# Altium schematic sheet [6]ftdi.SchDoc
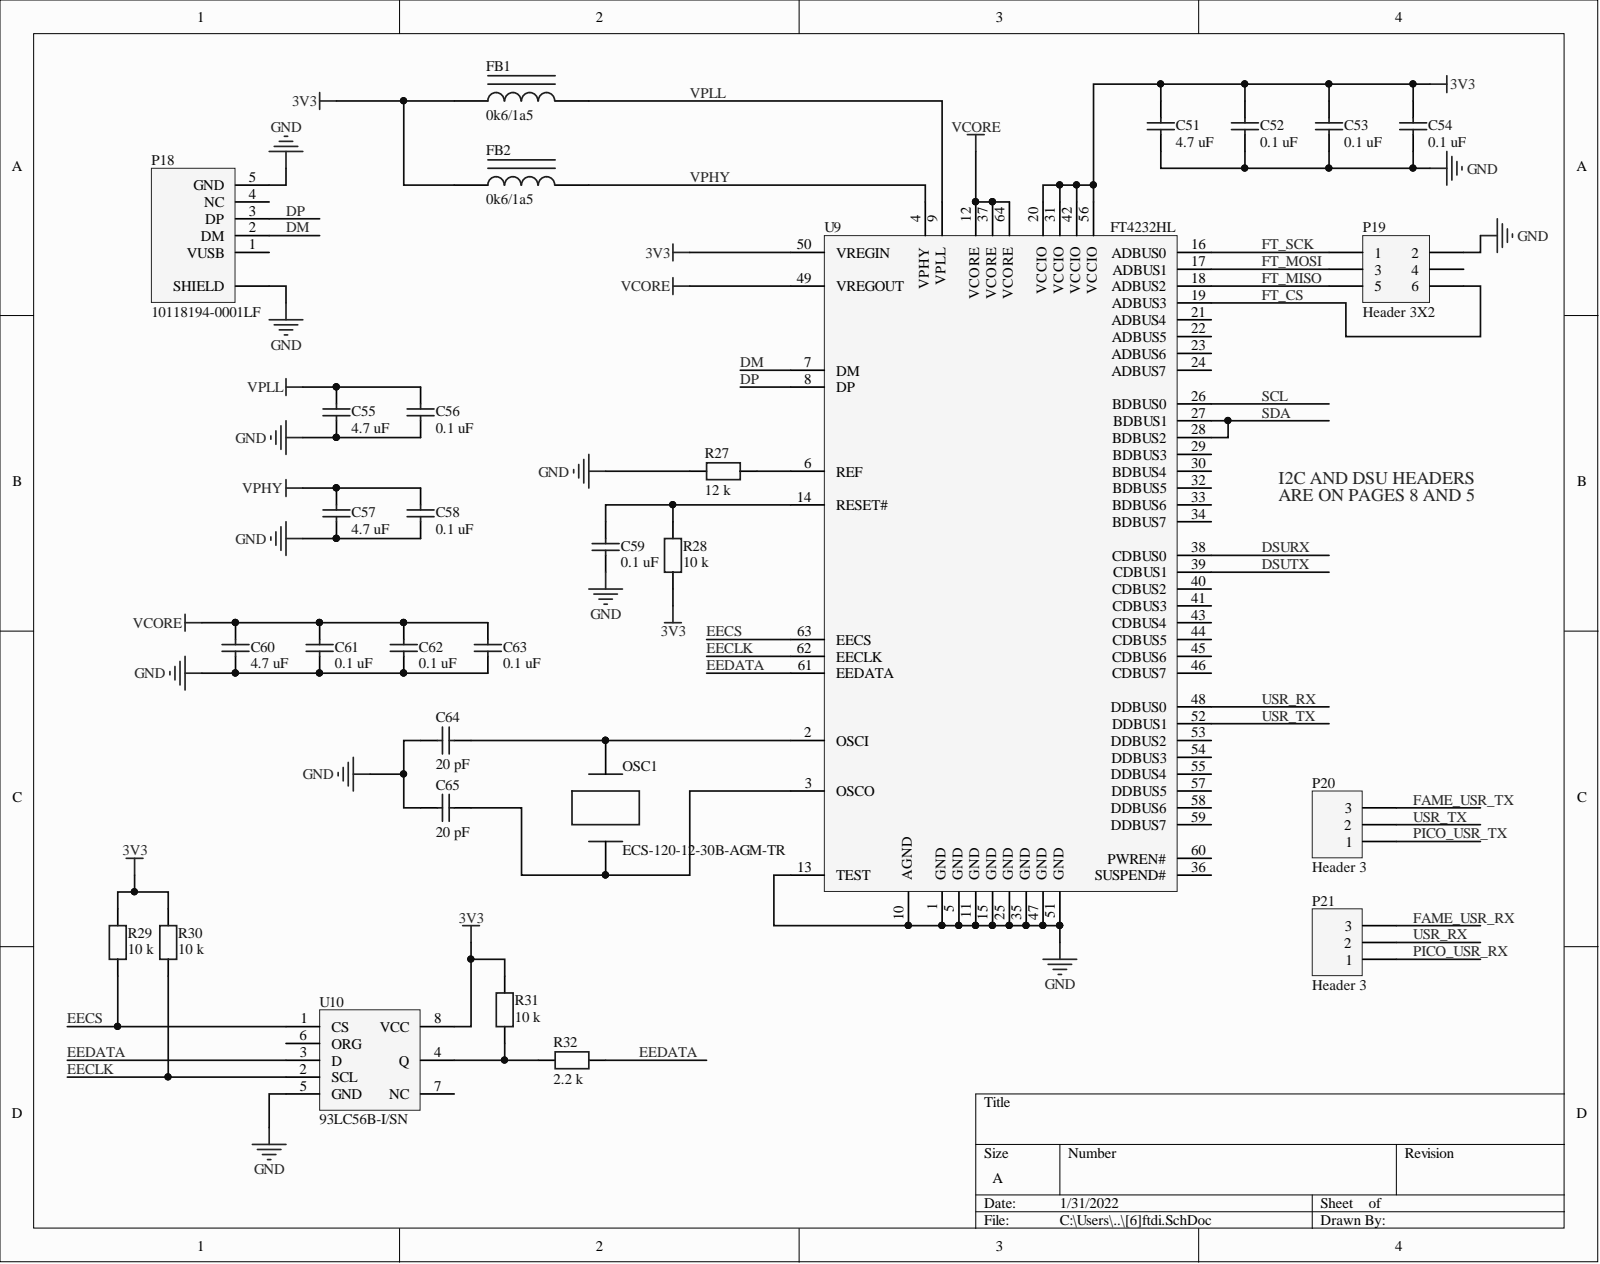
[diagram p0: [6]ftdi.SchDoc]

## Parts
C51  value 4.7 uF  [0603-CAP-ASM]  (hidden comment: Cap)
C52  value 0.1 uF  [0402-CAP-ASM]  (hidden comment: Cap)
C53  value 0.1 uF  [0402-CAP-ASM]  (hidden comment: Cap)
C54  value 0.1 uF  [0402-CAP-ASM]  (hidden comment: Cap)
C55  value 4.7 uF  [0603-CAP-ASM]  (hidden comment: Cap)
C56  value 0.1 uF  [0402-CAP-ASM]  (hidden comment: Cap)
C57  value 4.7 uF  [0603-CAP-ASM]  (hidden comment: Cap)
C58  value 0.1 uF  [0402-CAP-ASM]  (hidden comment: Cap)
C59  value 0.1 uF  [0402-CAP-ASM]  (hidden comment: Cap)
C60  value 4.7 uF  [0603-CAP-ASM]  (hidden comment: Cap)
C61  value 0.1 uF  [0402-CAP-ASM]  (hidden comment: Cap)
C62  value 0.1 uF  [0402-CAP-ASM]  (hidden comment: Cap)
C63  value 0.1 uF  [0402-CAP-ASM]  (hidden comment: Cap)
C64  value 20 pF  [0402-CAP-ASM]  (hidden comment: Cap)
C65  value 20 pF  [0402-CAP-ASM]  (hidden comment: Cap)
FB1  0k6/1a5  [1206-CAP-ASM]
FB2  0k6/1a5  [1206-CAP-ASM]
OSC1  ECS-120-12-30B-AGM-TR  [xtal 5032 ceramic]
P18  10118194-0001LF  [10118194-0001LF]
P19  Header 3X2  [HDR_2x3_2R54_V_M]
P20  Header 3  [Header 1x3 male]
P21  Header 3  [Header 1x3 male]
R27  value 12 k  [0402-RES-ASM]  (hidden comment: res)
R28  value 10 k  [0402-RES-ASM]  (hidden comment: res)
R29  value 10 k  [0402-RES-ASM]  (hidden comment: res)
R30  value 10 k  [0402-RES-ASM]  (hidden comment: res)
R31  value 10 k  [0402-RES-ASM]  (hidden comment: res)
R32  value 2.2 k  [0402-RES-ASM]  (hidden comment: res)
U9  FT4232HL  [LQFP64_N]
U10  93LC56B-I/SN  [SOIC-8]

## Nets
3V3: C51.2 C52.2 C53.2 C54.2 FB1.1 FB2.1 R28.1 R29.2 R30.2 R31.2 U9.20(VCCIO) U9.31(VCCIO) U9.42(VCCIO) U9.50(VREGIN) U9.56(VCCIO) U10.8(VCC)
GND: C51.1 C52.1 C53.1 C54.1 C55.1 C56.1 C57.1 C58.1 C59.1 C60.1 C61.1 C62.1 C63.1 C64.2 C65.2 P18.5(GND) P18.SHIELD P19.2 R27.2 U9.1(GND) U9.5(GND) U9.10(AGND) U9.11(GND) U9.13(TEST) U9.15(GND) U9.25(GND) U9.35(GND) U9.47(GND) U9.51(GND) U10.5(GND)
VCORE: C60.2 C61.2 C62.2 C63.2 U9.12(VCORE) U9.37(VCORE) U9.49(VREGOUT) U9.64(VCORE)
DM: P18.2(DM) U9.7(DM)
DP: P18.3(DP) U9.8(DP)
DSURX: U9.38(CDBUS0)
DSUTX: U9.39(CDBUS1)
EECLK: R30.1 U9.62(EECLK) U10.2(SCL)
EECS: R29.1 U9.63(EECS) U10.1(CS)
EEDATA: R32.1 U9.61(EEDATA) U10.3(D)
FAME_USR_RX: P21.3
FAME_USR_TX: P20.3
FT_CS: P19.6 U9.19(ADBUS3)
FT_MISO: P19.5 U9.18(ADBUS2)
FT_MOSI: P19.3 U9.17(ADBUS1)
FT_SCK: P19.1 U9.16(ADBUS0)
PICO_USR_RX: P21.1
PICO_USR_TX: P20.1
SCL: U9.26(BDBUS0)
SDA: U9.27(BDBUS1) U9.28(BDBUS2)
USR_RX: P21.2 U9.48(DDBUS0)
USR_TX: P20.2 U9.52(DDBUS1)
VPHY: C57.2 C58.2 FB2.2 U9.4(VPHY)
VPLL: C55.2 C56.2 FB1.2 U9.9(VPLL)
NetC59_2: C59.2 R28.2 U9.14(RESET#)
NetC64_1: C64.1 OSC1.2 U9.2(OSCI)
NetC65_1: C65.1 OSC1.1 U9.3(OSCO)
NetR27_1: R27.1 U9.6(REF)
NetR31_1: R31.1 R32.2 U10.4(Q)

Not connected: P18 1(VUSB) 4(NC); P19 4; U9 21(ADBUS4) 22(ADBUS5) 23(ADBUS6) 24(ADBUS7) 29(BDBUS3) 30(BDBUS4) 32(BDBUS5) 33(BDBUS6) 34(BDBUS7) 36(SUSPEND#) 40(CDBUS2) 41(CDBUS3) 43(CDBUS4) 44(CDBUS5) 45(CDBUS6) 46(CDBUS7) 53(DDBUS2) 54(DDBUS3) 55(DDBUS4) 57(DDBUS5) 58(DDBUS6) 59(DDBUS7) 60(PWREN#); U10 6(ORG) 7(NC)

## Library data of the parts
C51 C55 C57 C60 = Cap: CAP CER 4.7UF 25V JB 0603; Component Kind: Standard; Library Name: Miscellaneous Devices.IntLib; Supplier Part Number: 445-11253-1-ND
C52-C54 C56 C58 C59 C61-C63 = Cap: CAP CER 0.1UF 10V X5R 0402; Component Kind: Standard; Library Name: Miscellaneous Devices.IntLib; Supplier Part Number: 587-1227-1-ND
C64 C65 = Cap: CAP CER 20PF 50V NP0 0402; Component Kind: Standard; Library Name: Miscellaneous Devices.IntLib; Supplier Part Number: 478-10147-1-ND
FB1 FB2 = Inductor Iron: FERRITE BEAD 600 OHM 1206 1LN; Component Kind: Standard; Library Name: Miscellaneous Devices.IntLib; Supplier Part Number: 490-16491-1-ND
OSC1 = ECS-120-12-30B-AGM-TR: CRYSTAL 12.0000MHZ 12PF SMD; Component Kind: Standard; Library Name: Mylib..SchLib; Supplier Part Number: XC2676CT-ND
P18 = 10118194-0001LF: CONN RCPT USB2.0 MICRO B SMD R/A; Component Kind: Standard; Library Name: Mylib..SchLib; Supplier Part Number: 609-4618-1-ND
P19 = Header 3X2: CONN HEADER VERT 6POS 2.54MM; Component Kind: Standard; Library Name: Miscellaneous Connectors.IntLib; Supplier Part Number: SAM10846-ND
P20 P21 = Header 3: CONN HEADER VERT 3POS 2.54MM; Component Kind: Standard; Library Name: Miscellaneous Connectors.IntLib; Supplier Part Number: SAM1031-03-ND
R27 = RESISTOR: RES SMD 12K OHM 0.1% 1/16W 0402; Component Kind: Standard; Library Name: Mylib..SchLib; Supplier Part Number: YAG1354CT-ND
R28-R31 = RESISTOR: RES SMD 10K OHM 5% 1/16W 0402; Component Kind: Standard; Library Name: Mylib..SchLib; Supplier Part Number: 311-10KJRCT-ND
R32 = RESISTOR: RES 2.2K OHM 5% 1/16W 0402; Component Kind: Standard; Library Name: Mylib..SchLib; Supplier Part Number: 311-2.2KJRCT-ND
U9 = FT4232HL: IC USB HS QUAD UART/SYNC 64-LQFP; Component Kind: Standard; Library Name: Mylib..SchLib; Supplier Part Number: 768-1026-1-ND
U10 = 93LC56B-I/SN: IC EEPROM 2KBIT SPI 2MHZ 8SOIC; Component Kind: Standard; Library Name: Mylib..SchLib; Supplier Part Number: 93LC56B-I/SN-ND
